# Altium schematic sheet DAC_PWW_Outputs.SchDoc(DAC_PWW_Outputs)
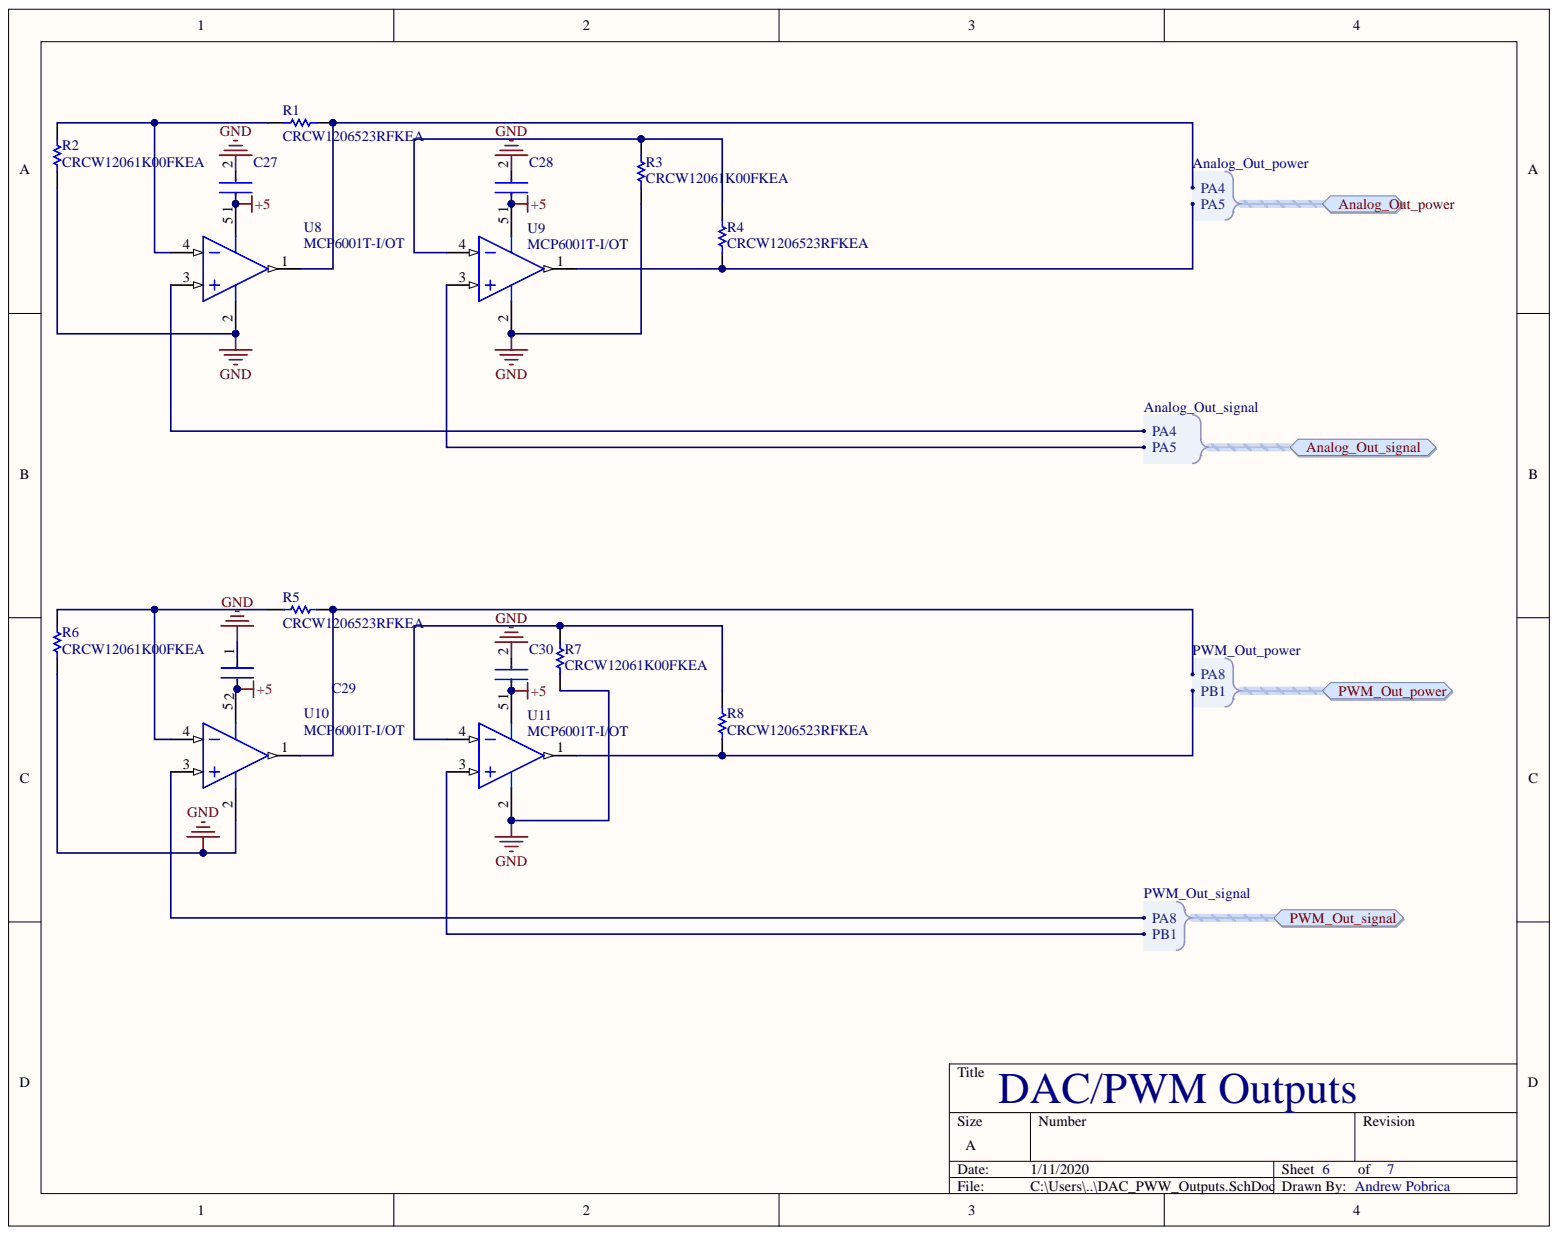
[diagram p0: DAC_PWW_Outputs.SchDoc]

## Parts
C27  C0603C104K5RACTU  [Kemet_C0805_IPC_A]  (value not drawn)
C28  C0603C104K5RACTU  [Kemet_C0805_IPC_A]  (value not drawn)
C29  C0603C104K5RACTU  [Kemet_C0805_IPC_A]  (value not drawn)
C30  C0603C104K5RACTU  [Kemet_C0805_IPC_A]  (value not drawn)
R1  CRCW1206523RFKEA  [RESC3216X60X45NL10T20]
R2  CRCW12061K00FKEA  [RESC3216X60X45ML15T20]
R3  CRCW12061K00FKEA  [RESC3216X60X45ML15T20]
R4  CRCW1206523RFKEA  [RESC3216X60X45NL10T20]
R5  CRCW1206523RFKEA  [RESC3216X60X45NL10T20]
R6  CRCW12061K00FKEA  [RESC3216X60X45ML15T20]
R7  CRCW12061K00FKEA  [RESC3216X60X45ML15T20]
R8  CRCW1206523RFKEA  [RESC3216X60X45NL10T20]
U8  MCP6001T-I/OT  [SOT-23-OT5_CUSTOM]
U9  MCP6001T-I/OT  [SOT-23-OT5_CUSTOM]
U10  MCP6001T-I/OT  [SOT-23-OT5_CUSTOM]
U11  MCP6001T-I/OT  [SOT-23-OT5_CUSTOM]

## Ports
Analog_Out_power, Analog_Out_power.PA4, Analog_Out_power.PA5, Analog_Out_signal, Analog_Out_signal.PA4, Analog_Out_signal.PA5, PWM_Out_power, PWM_Out_power.PA8, PWM_Out_power.PB1, PWM_Out_signal, PWM_Out_signal.PA8, PWM_Out_signal.PB1

## Nets
+5: C27.1 C28.1 C29.2 C30.1 U8.5 U9.5 U10.5 U11.5
GND: C27.2 C28.2 C29.1 C30.2 R2.2 R3.2 R6.2 R7.2 U8.2 U9.2 U10.2 U11.2
NetR1_1: R1.1 U8.1 ; port Analog_Out_power
NetR1_2: R1.2 R2.1 U8.4
NetR3_1: R3.1 R4.2 U9.4
NetR4_1: R4.1 U9.1 ; port Analog_Out_power
NetR5_1: R5.1 U10.1 ; port PWM_Out_power
NetR5_2: R5.2 R6.1 U10.4
NetR7_1: R7.1 R8.2 U11.4
NetR8_1: R8.1 U11.1 ; port PWM_Out_power
NetU8_3: U8.3 ; port Analog_Out_signal
NetU9_3: U9.3 ; port Analog_Out_signal
NetU10_3: U10.3 ; port PWM_Out_signal
NetU11_3: U11.3 ; port PWM_Out_signal

## Library data of the parts
R1 R4 R5 R8 = CMP-2003-02266-1: Manufacturer: Vishay Dale; Part Number: CRCW1206523RFKEA; Library Name: Altium Content Vault; Component Kind: Standard
R2 R3 R6 R7 = CMP-2100-03616-1: Chip Resistor, 1 KOhm, +/- 1%, 0.25 W, -55 to 155 degC, 1206 (3216 Metric), RoHS, Tape and Reel; Manufacturer: Vishay Semiconductor; Voltage Rating (DC): 200V; Temperature Coefficient: 100ppm/degreesC; Resistance: 1KOhm; Power Rating: 250mW; Width: 0.063inch; Weight: 0.000353oz; Voltage Rating: 200V; Tolerance: 1%; Termination: SMD/SMT; RoHSCompliant: true; REACH SVHC: Unknown; Radiation Hardening: No; Packaging: TapeandReel; Package Reference: 1206.0; Package Quantity: 10; Package Description: 2-Pin Surface Mount Device, Body 3.15 x 1.6 mm; Number of Terminations: 2; Number of Pins: 2; Mount: SurfaceMount; Min Operating Temperature: -55degC; Military Standard: Not; Max Power Dissipation: 250mW; Max Operating Temperature: 155degC; Manufacturer URL: http://www.vishay.com/; Library Name: Altium Content Vault; Length: 3.2mm; Lead Free: LeadFree; Height: 0.55mm; Depth: 1.6mm; Datasheet Version: 41061.0; Datasheet URL: http://www.farnell.com/datasheets/1720486.pdf; Contact Plating: Tin; Composition: ThickFilm; Component Kind: Standard; Case Code (Metric): 3216; Case Code (Imperial): 1206; Case/Package: 1206
U8 U11 = CMP-0187-00462-2: 1 MHz, Low-Power Operational Amplifier, 5-Pin SOT-23, Industrial Temperature, Tape and Reel; RevisionNote: URLs added.; PackageVersion: revBB, Aug-2009; PackageReference: SOT-23-OT5; PackageDescription: 5-Lead Plastic Small Outline Transistor (OT) [SOT-23]; Library Name: Components - 19.05.19 - 16.13.SchLib; DatasheetVersion: revJ, Nov-2009; ComponentLink3URL: http://www.microchip.com/stellent/groups/techpub_sg/documents/packagingspec/en012702.pdf; ComponentLink3Description: Package Specification; ComponentLink2URL: http://ww1.microchip.com/downloads/en/DeviceDoc/21733j.pdf; ComponentLink2Description: Datasheet; ComponentLink1URL: http://www.microchip.com/; ComponentLink1Description: Manufacturer URL; Component Kind: Standard; Code_IPC: SOT23-5
U9 U10 = AMP-OPA-MCP6001-SOT5: 1 MHz, Low-Power Operational Amplifier, 5-Pin SOT-23, Industrial Temperature, Tape and Reel; RevisionNote: URLs added.; PackageVersion: revBB, Aug-2009; PackageReference: SOT-23-OT5; PackageDescription: 5-Lead Plastic Small Outline Transistor (OT) [SOT-23]; Library Name: Components - 19.05.19 - 16.13.SchLib; DatasheetVersion: revJ, Nov-2009; ComponentLink3URL: http://www.microchip.com/stellent/groups/techpub_sg/documents/packagingspec/en012702.pdf; ComponentLink3Description: Package Specification; ComponentLink2URL: http://ww1.microchip.com/downloads/en/DeviceDoc/21733j.pdf; ComponentLink2Description: Datasheet; ComponentLink1URL: http://www.microchip.com/; ComponentLink1Description: Manufacturer URL; Component Kind: Standard; Code_IPC: SOT23-5
C27-C30 = C0603C104K5RACTU: Component Kind: Standard; Library Name: parts/UNK_Kemet_C0603C104K5RACTU_eec.SchLib
